# Altium schematic sheet connectors.SchDoc
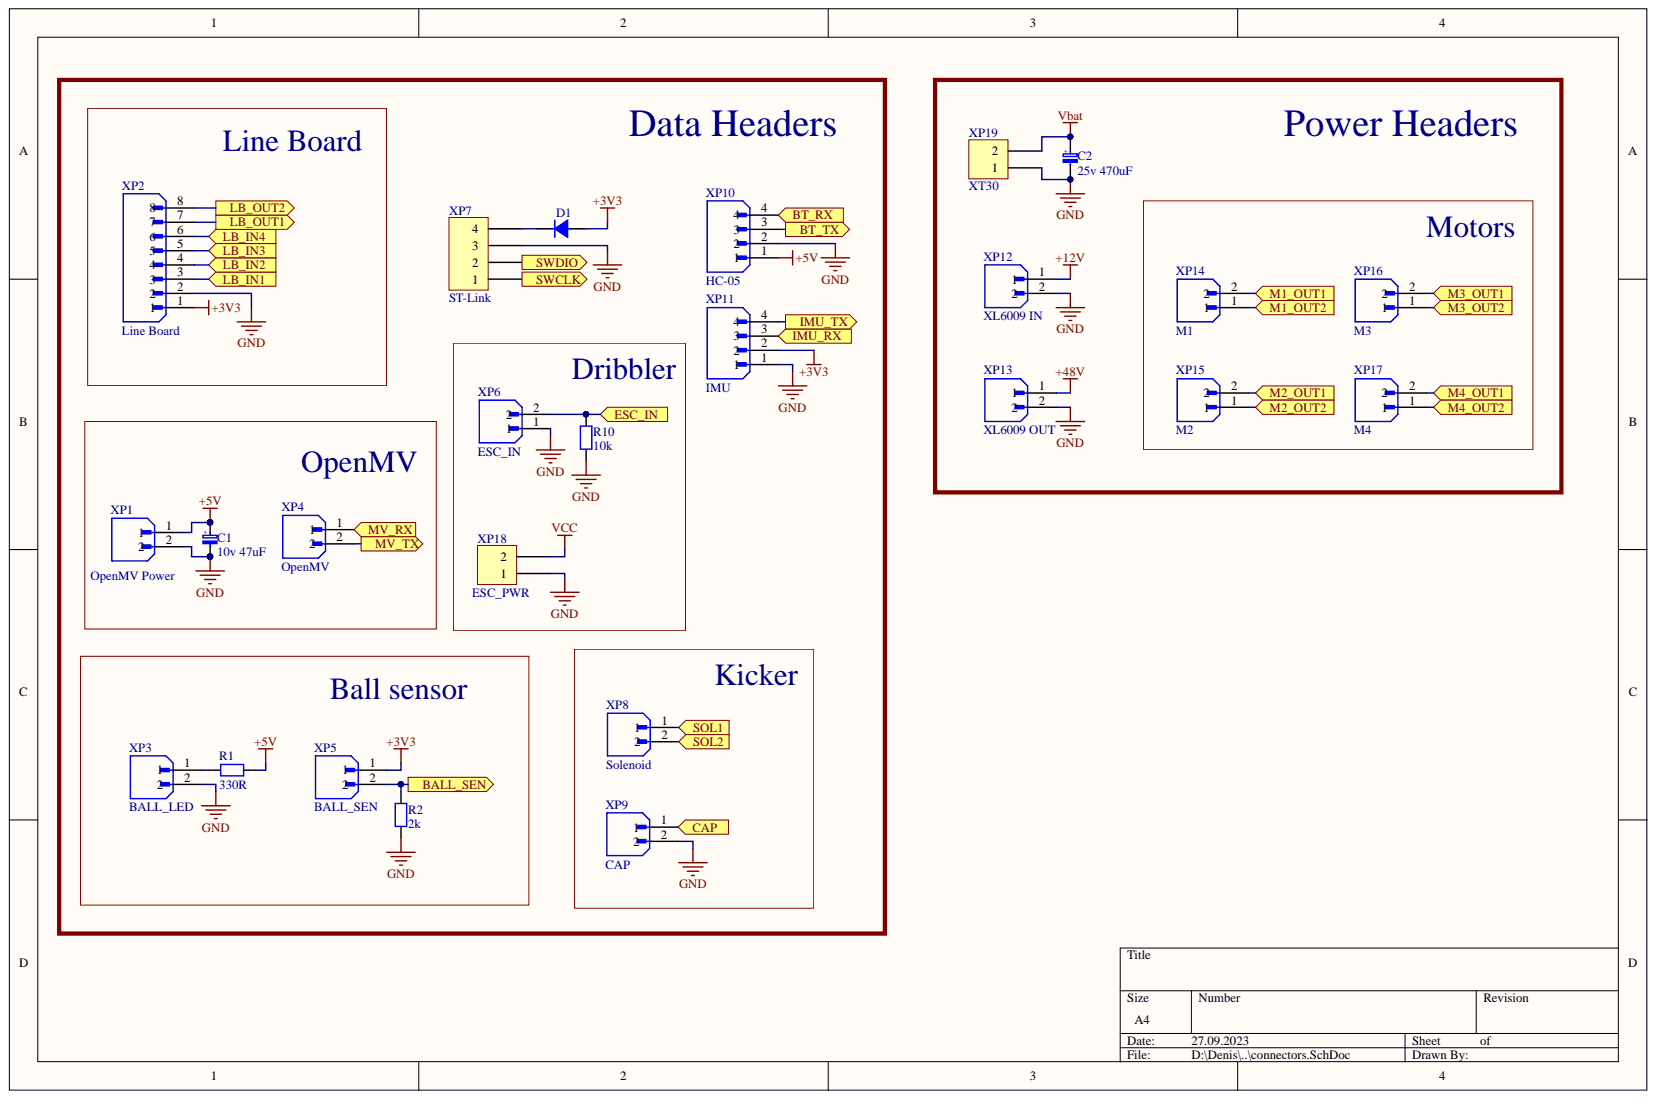
[diagram p0: connectors.SchDoc]

## Parts
C1  10v 47uF  [CAP_3.2x1.6h1.2]
C2  25v 470uF  [D8C3.5H12]
D1  Diode SMD  [DO-214AC]  (value not drawn)
R1  330R  [res0402]
R2  2k  [res0402]
R10  10k  [res0402]
XP1  OpenMV Power  [JST_XH-2]
XP2  Line Board  [JST_XH-8]
XP3  BALL_LED  [JST_XH-2]
XP4  OpenMV  [JST_XH-2]
XP5  BALL_SEN  [JST_XH-2]
XP6  ESC_IN  [JST_XH-2]
XP7  ST-Link  [4x2.54]
XP8  Solenoid  [JST_XH-2]
XP9  CAP  [JST_XH-2]
XP10  HC-05  [JST_XH-4]
XP11  IMU  [JST_XH-4]
XP12  XL6009 IN  [JST_XH-2]
XP13  XL6009 OUT  [JST_XH-2]
XP14  M1  [JST_XH-2]
XP15  M2  [JST_XH-2]
XP16  M3  [JST_XH-2]
XP17  M4  [JST_XH-2]
XP18  ESC_PWR  [XT30_3D]
XP19  XT30  [XT30_3D]

## Ports
BALL_SEN, BT_RX, BT_TX, CAP, ESC_IN, IMU_RX, IMU_TX, LB_IN1, LB_IN2, LB_IN3, LB_IN4, LB_OUT1, LB_OUT2, M1_OUT1, M1_OUT2, M2_OUT1, M2_OUT2, M3_OUT1, M3_OUT2, M4_OUT1, M4_OUT2, MV_RX, MV_TX, SOL1, SOL2, SWCLK, SWDIO

## Nets
+3V3: D1.1 XP2.1 XP5.1 XP11.2
+5V: C1.2 R1.2 XP1.1 XP10.1
+12V: XP12.1
+48V: XP13.1
GND: C1.1 C2.2 R2.2 R10.1 XP1.2 XP2.2 XP3.2 XP6.1 XP7.3 XP9.2 XP10.2 XP11.1 XP12.2 XP13.2 XP18.1 XP19.1
Vbat: C2.1 XP19.2
VCC: XP18.2
BALL_SEN: R2.1 XP5.2 ; port BALL_SEN
BT_RX: XP10.4 ; port BT_RX
BT_TX: XP10.3 ; port BT_TX
CAP: XP9.1 ; port CAP
ESC_IN: R10.2 XP6.2 ; port ESC_IN
IMU_RX: XP11.3 ; port IMU_RX
IMU_TX: XP11.4 ; port IMU_TX
LB_IN1: XP2.3 ; port LB_IN1
LB_IN2: XP2.4 ; port LB_IN2
LB_IN3: XP2.5 ; port LB_IN3
LB_IN4: XP2.6 ; port LB_IN4
LB_OUT1: XP2.7 ; port LB_OUT1
LB_OUT2: XP2.8 ; port LB_OUT2
M1_OUT1: XP14.2 ; port M1_OUT1
M1_OUT2: XP14.1 ; port M1_OUT2
M2_OUT1: XP15.2 ; port M2_OUT1
M2_OUT2: XP15.1 ; port M2_OUT2
M3_OUT1: XP16.2 ; port M3_OUT1
M3_OUT2: XP16.1 ; port M3_OUT2
M4_OUT1: XP17.2 ; port M4_OUT1
M4_OUT2: XP17.1 ; port M4_OUT2
MV_RX: XP4.1 ; port MV_RX
MV_TX: XP4.2 ; port MV_TX
SOL1: XP8.1 ; port SOL1
SOL2: XP8.2 ; port SOL2
SWCLK: XP7.1 ; port SWCLK
SWDIO: XP7.2 ; port SWDIO
NetD1_2: D1.2 XP7.4
NetR1_1: R1.1 XP3.1

## Library data of the parts
C1 = Cap Tantal: Component Kind: Standard; Library Name: GKirik.SchLib
C2 = Cap Aluminum: Component Kind: Standard; Library Name: GKirik.SchLib
R1 R2 R10 = Resistor SMD: Component Kind: Standard; Library Name: GKirik.SchLib
XP1 XP3-XP6 XP8 XP9 XP12-XP17 = JST-XH-2: JST_B2B-XH-A(LF)(SN); Component Kind: Standard; Library Name: Asterisk.SchLib
XP2 = JST-XH-8: JST_B8B-XH-A(LF)(SN); Component Kind: Standard; Library Name: Asterisk.SchLib
XP7 = Header 4: Component Kind: Standard; Library Name: GKirik.SchLib
XP10 XP11 = JST_XH-4: JST_B4B-XH-A(LF)(SN); Component Kind: Standard; Library Name: Asterisk.SchLib
XP18 XP19 = Power connectors: Component Kind: Standard; Library Name: GKirik.SchLib
D1 = Diode SMD: Component Kind: Standard; Library Name: GKirik.SchLib
